# Altium schematic sheet 5.04.51805A02_STMFAN&RGB.SchDoc(5.04.51805A02_STMFAN&RGB)
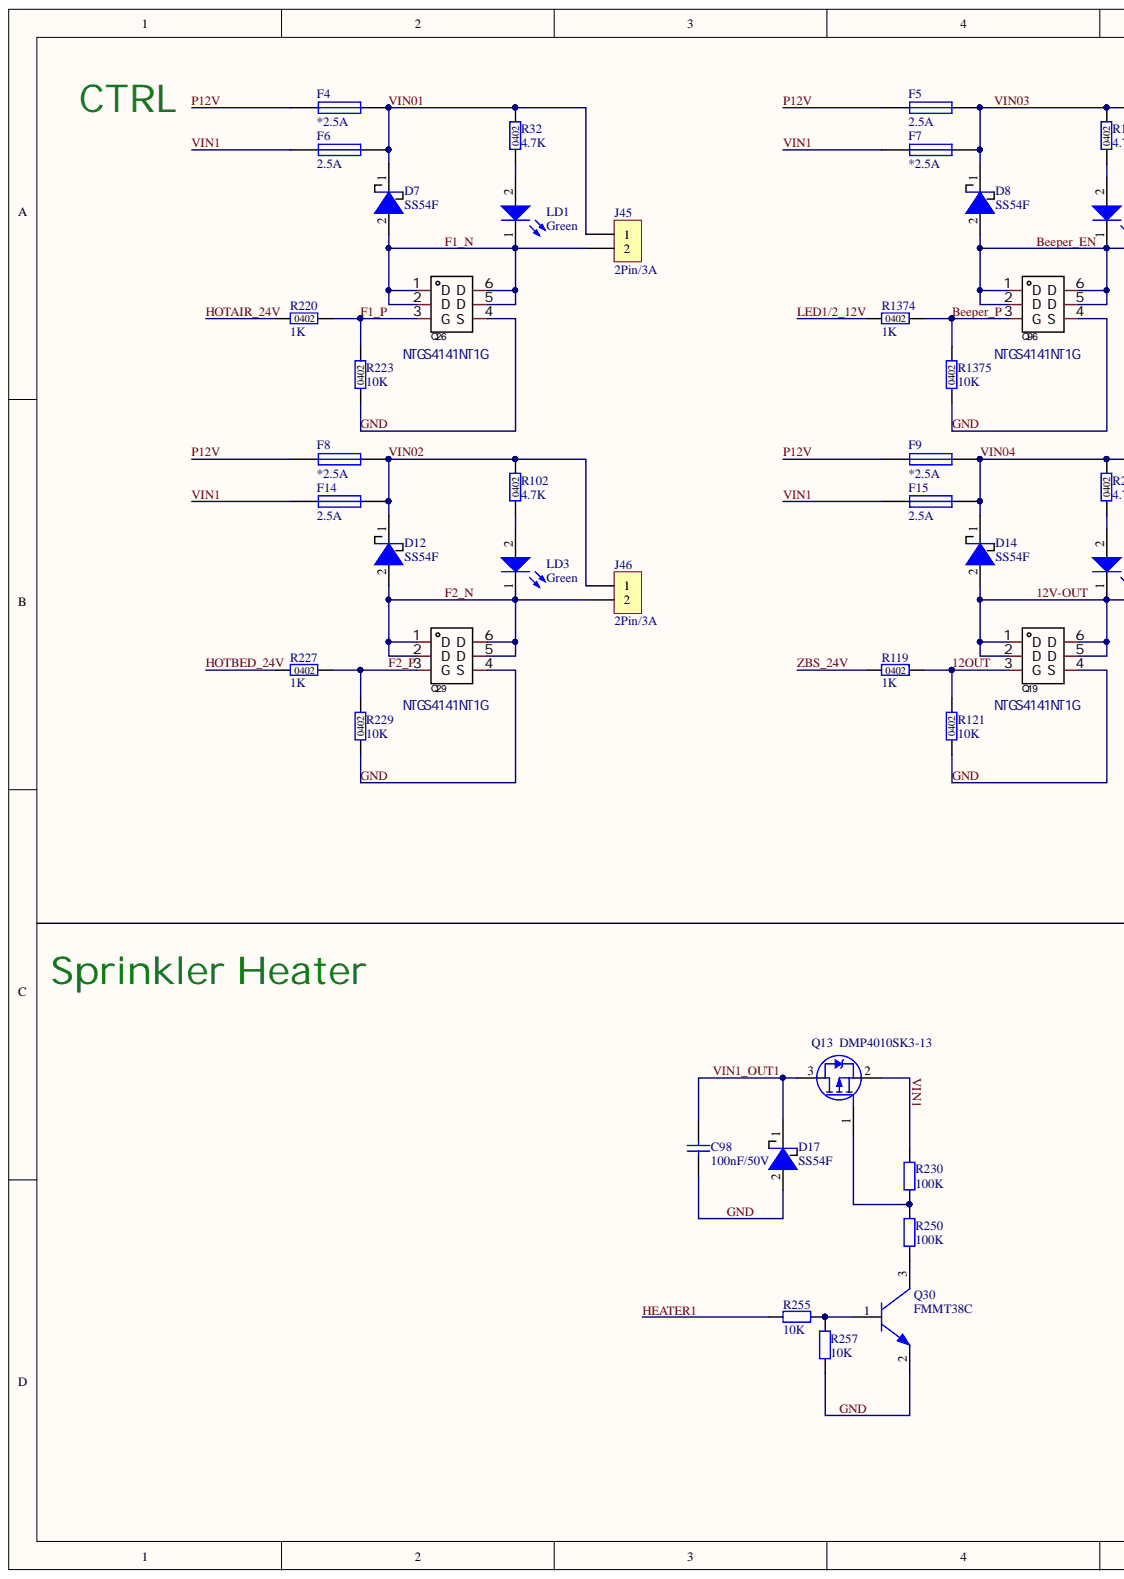
[diagram p0: 5.04.51805A02_STMFAN&RGB.SchDoc, left]
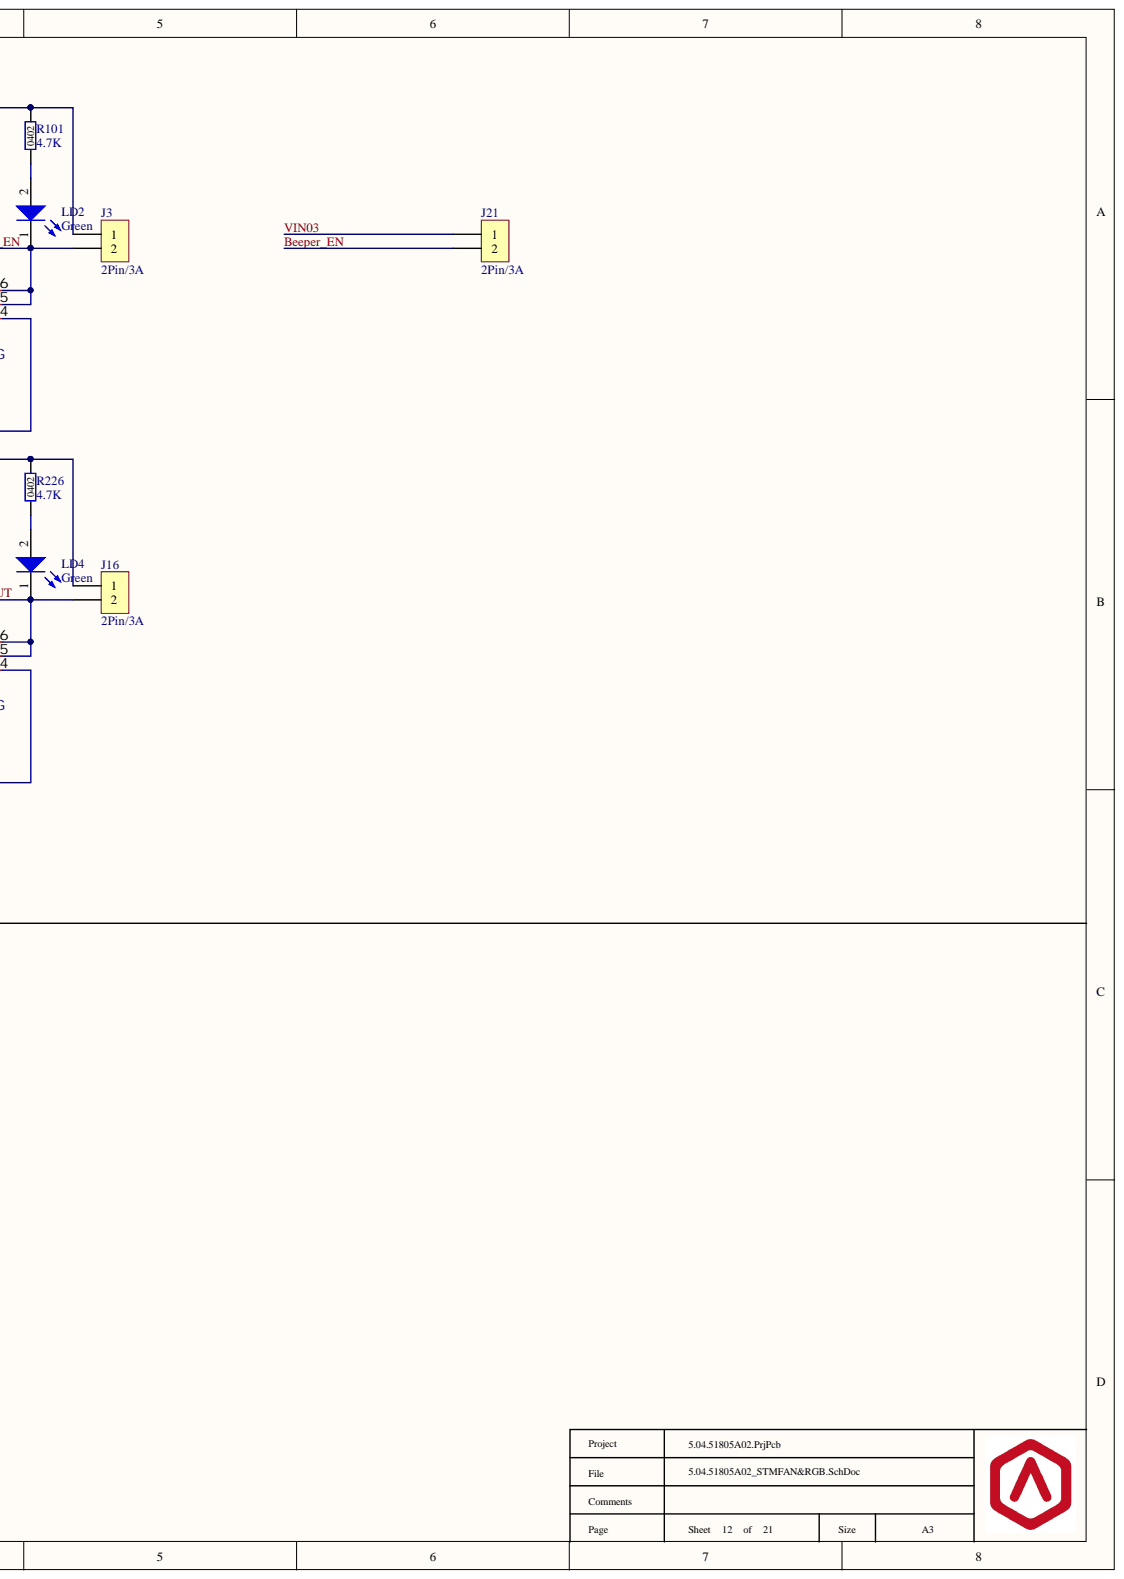
[diagram p1: 5.04.51805A02_STMFAN&RGB.SchDoc, right]

## Parts
C98  100nF/50V  [C0603]
D7  SS54F  [SMAF_L3.5-W2.6-LS4.7-RD]
D8  SS54F  [SMAF_L3.5-W2.6-LS4.7-RD]
D12  SS54F  [SMAF_L3.5-W2.6-LS4.7-RD]
D14  SS54F  [SMAF_L3.5-W2.6-LS4.7-RD]
D17  SS54F  [SMAF_L3.5-W2.6-LS4.7-RD]
F4  *2.5A  [F0603]
F5  2.5A  [F0603]
F6  2.5A  [F0603]
F7  *2.5A  [F0603]
F8  *2.5A  [F0603]
F9  *2.5A  [F0603]
F14  2.5A  [F0603]
F15  2.5A  [F0603]
J3  2Pin/3A  [B2B-XH-A(LF)(SN)]
J16  2Pin/3A  [B2B-XH-A(LF)(SN)]
J21  2Pin/3A  [B2B-XH-A(LF)(SN)]
J45  2Pin/3A  [B2B-XH-A(LF)(SN)]
J46  2Pin/3A  [B2B-XH-A(LF)(SN)]
LD1  Green  [LED0603_2]
LD2  Green  [LED0603_2]
LD3  Green  [LED0603_2]
LD4  Green  [LED0603_2]
Q13  DMP4010SK3-13  [TO-252-3]
Q19  NTGS4141NT1G  [TSOP-6]
Q26  NTGS4141NT1G  [TSOP-6]
Q29  NTGS4141NT1G  [TSOP-6]
Q30  FMMT38C  [SOT-23-3]
Q96  NTGS4141NT1G  [TSOP-6]
R32  4.7K  [R0402]
R101  4.7K  [R0402]
R102  4.7K  [R0402]
R119  1K  [R0402]
R121  10K  [R0402]
R220  1K  [R0402]
R223  10K  [R0402]
R226  4.7K  [R0402]
R227  1K  [R0402]
R229  10K  [R0402]
R230  100K  [R0402]
R250  100K  [R0402]
R255  10K  [R0402]
R257  10K  [R0402]
R1374  1K  [R0402]
R1375  10K  [R0402]

## Nets
12OUT: Q19.3(G) R119.2 R121.2
12V-OUT: D14.2 J16.2 LD4.1 Q19.1(D) Q19.2(D) Q19.5(D) Q19.6(D)
BEEPER_EN: D8.2 J3.2 J21.2 LD2.1 Q96.1(D) Q96.2(D) Q96.5(D) Q96.6(D)
BEEPER_P: Q96.3(G) R1374.2 R1375.2
F1_N: D7.2 J45.2 LD1.1 Q26.1(D) Q26.2(D) Q26.5(D) Q26.6(D)
F1_P: Q26.3(G) R220.2 R223.2
F2_N: D12.2 J46.2 LD3.1 Q29.1(D) Q29.2(D) Q29.5(D) Q29.6(D)
F2_P: Q29.3(G) R227.2 R229.2
GND: C98.1 D17.2 Q19.4(S) Q26.4(S) Q29.4(S) Q30.2 Q96.4(S) R121.1 R223.1 R229.1 R257.2 R1375.1
HEATER1: R255.1
HOTAIR_24V: R220.1
HOTBED_24V: R227.1
LED1/2_12V: R1374.1
P12V: F4.1 F5.1 F8.1 F9.1
VIN01: D7.1 F4.2 F6.2 J45.1 R32.2
VIN1: F6.1 F7.1 F14.1 F15.1 Q13.2 R230.2
VIN1_OUT1: C98.2 D17.1 Q13.3
VIN02: D12.1 F8.2 F14.2 J46.1 R102.2
VIN03: D8.1 F5.2 F7.2 J3.1 J21.1 R101.2
VIN04: D14.1 F9.2 F15.2 J16.1 R226.2
ZBS_24V: R119.1
NetLD1_2: LD1.2 R32.1
NetLD2_2: LD2.2 R101.1
NetLD3_2: LD3.2 R102.1
NetLD4_2: LD4.2 R226.1
NetQ13_1: Q13.1 R230.1 R250.2
NetQ30_1: Q30.1 R255.2 R257.1
NetQ30_3: Q30.3 R250.1

## Library data of the parts
C98 = C0603_100nF_50V: Ceramic Capacitor, 100nF, ±10%, 50V, -55°C~125°C, C0603; Manufacturer1: Yageo; ManufacturerPartNumber1: CC0603KRX7R9BB104; Code: 5192C104; Component Kind: Standard; Library Name: Raise3D_Capacitor.SCHLIB
D7 D8 D12 D14 D17 = SBD_SS54F: SBD,SS54F,40V,550mV,5A,SMB,-65°C~150°C; Manufacturer1: Diodes; Code: 530300XX; Component Kind: Standard; ManufacturerPartNumber1: SS54F; Library Name: Raise3D_Diode.IntLib
F4-F9 F14 F15 = Fuse_2.5A: 保险丝,SF-0603S250M-2,32V,2.5A,35A,32mOhms,F0603,-55°C~125°C; Manufacturer1: Bourns; Code: 56010008; Component Kind: Standard; ManufacturerPartNumber1: SF-0603S250M-2; Library Name: Raise3D_Fuse.IntLib
J3 J16 J21 J45 J46 = JW_B2B-XH-A(LF)(SN): 线对板连接器,2PINS,Pitch2.5mm,TH,OR,3A,白,-25°C~85°C; Manufacturer1: JST; Code: 55010012; Component Kind: Standard; Manufacturer2: 红星辰（HXT）; ManufacturerPartNumber1: B2B-XH-A(LF)(SN); ManufacturerPartNumber2: 25000-02A-T0P; Library Name: Raise3D_Connector.IntLib
LD1-LD4 = LED_APT1608CGCK: LED,APT1608CGCK,Green,2.5V,TOP,LED0603,-40°C~85°C; Manufacturer1: Kingbright; Code: 53010003; Component Kind: Standard; ManufacturerPartNumber1: APT1608CGCK; Library Name: Raise3D_Diode.IntLib
Q13 = PMOS_DMP4010SK3-13-ND: P-MOSFET, DMP4010SK3-13-ND, 1Channel, Vds=40V, Id=50A, Vgs=+/-25V, TO-252-3,-55°C~150°C; Manufacturer1: DIODES; Code: 54020003; Component Kind: Standard; ManufacturerPartNumber1: DMP4010SK3-13-ND; Library Name: Raise3D_MOS&BJT.IntLib
Q19 Q26 Q29 Q96 = NMOS_NTGS4141NT1G: N-MOSFET,NTGS4141NT1G,1 Channel.Vds=30V,Id=5A,Vgs=+/-20V,TSOP-6,-55℃~150℃; Manufacturer1: ONSemiconductor; Code: 54010004; Component Kind: Standard; ManufacturerPartNumber1: NTGS4141NT1G; Library Name: Raise3D_MOS&BJT.IntLib
Q30 = NPN_FMMT38C: BJT，NPN, FMMT38C, 1Channel, Vceo=60V, Id=300mA, SOT-23-3,-55℃~150℃; Manufacturer1: DIODES; Code: 54030002; Component Kind: Standard; ManufacturerPartNumber1: FMMT38C; Library Name: Raise3D_MOS&BJT.SCHLIB
R32 R101 R102 R226 = R0402_4.7K: Resistor 4.7Kohm,5%,1/16W,R0402; Manufacturer1: Yageo; ManufacturerPartNumber1: RC0402JR-074K7L; Code: 501104K7; Component Kind: Standard; Manufacturer2: Samsung; ManufacturerPartNumber2: RCS1005J472CS; Library Name: Raise3D_Resistor.IntLib
R119 R220 R227 R1374 = R0402_1K: Resistor 1Kohm,5%,1/16W,R0402; Manufacturer1: Yageo; ManufacturerPartNumber1: RC0402JR-071KL; Code: 5011001K; Component Kind: Standard; Library Name: Raise3D_Resistor.SCHLIB
R121 R223 R229 R255 R257 R1375 = R0402_10K: Resistor 10Kohm,1%,1/16W,R0402; Manufacturer1: Yageo; ManufacturerPartNumber1: RC0402FR-0710KL; Code: 5001010K; Component Kind: Standard; Manufacturer2: Samsung; ManufacturerPartNumber2: RC1005F103CS
  R121 R223 R229 R1375: Library Name: Raise3D_Resistor.IntLib
  R255 R257: Library Name: Raise3D_Resistor.SCHLIB
R230 R250 = R0402_100K: Resistor 100Kohm,1%,1/16W,R0402; Manufacturer1: Yageo; ManufacturerPartNumber1: RC0402FR-07100KL; Code: 5001100K; Component Kind: Standard; Manufacturer2: Samsung; ManufacturerPartNumber2: RC1005F104CS; Library Name: Raise3D_Resistor.SCHLIB
